# Altium schematic sheet Sheet1.SchDoc
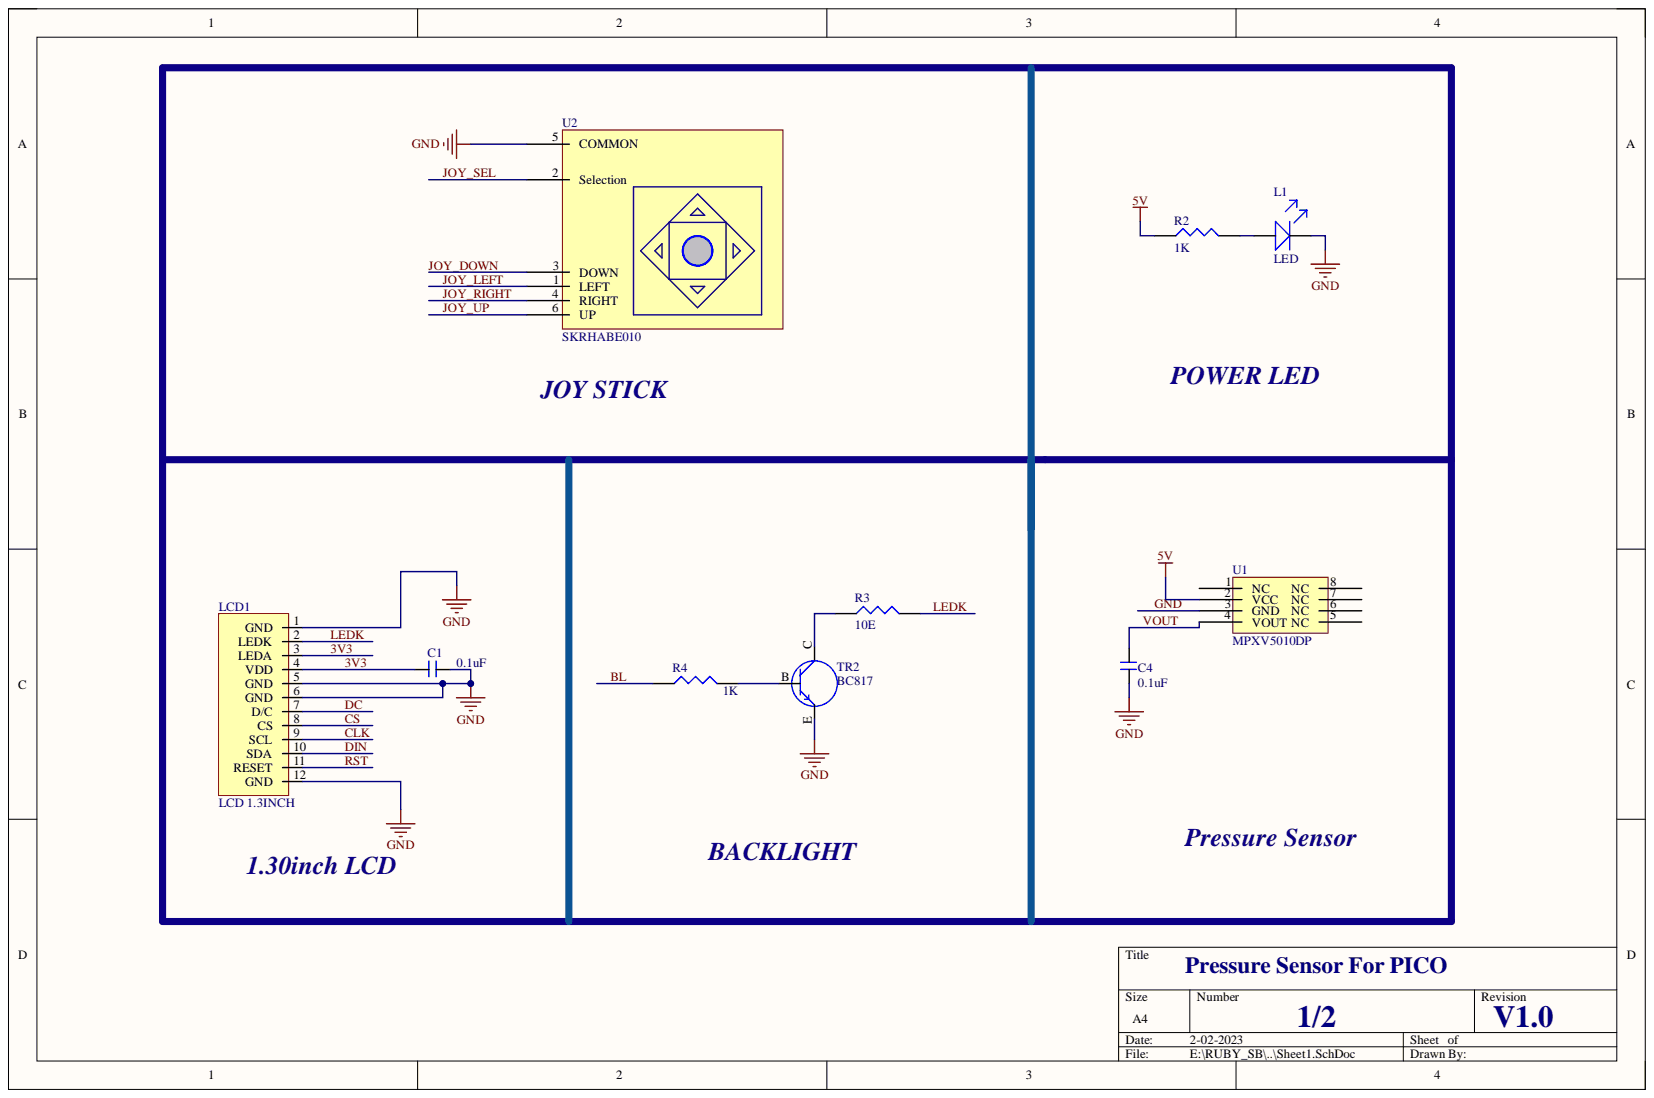
[diagram p0: Sheet1.SchDoc]

## Parts
C1  0.1uF  [C_0603]
C4  0.1uF  [C_0603]
L1  LED  [LED_0603]
LCD1  LCD 1.3INCH  [1.3inch LCD]
R2  1K  [R_0603]
R3  10E  [R_0603]
R4  1K  [R_0603]
TR2  BC817  [SOT23]
U1  MPXV5010DP  [MPXV5010DP]
U2  SKRHABE010  [JOY_STICK]

## Nets
5V: R2.1 U1.2(VCC)
3V3: C1.2 LCD1.3(LEDA) LCD1.4(VDD)
BL: R4.1
CLK: LCD1.9(SCL)
CS: LCD1.8(CS)
DC: LCD1.7(D/C)
DIN: LCD1.10(SDA)
GND: C1.1 C4.1 L1.K LCD1.1(GND) LCD1.5(GND) LCD1.6(GND) LCD1.12(GND) TR2.E U1.3(GND) U2.5(COMMON)
JOY_DOWN: U2.3(DOWN)
JOY_LEFT: U2.1(LEFT)
JOY_RIGHT: U2.4(RIGHT)
JOY_SEL: U2.2(Selection)
JOY_UP: U2.6(UP)
LEDK: LCD1.2(LEDK) R3.2
RST: LCD1.11(RESET)
VOUT: C4.2 U1.4(VOUT)
NetL1_A: L1.A R2.2
NetR3_1: R3.1 TR2.C
NetR4_2: R4.2 TR2.B

Not connected: U1 1(NC) 5(NC) 6(NC) 7(NC) 8(NC)

## Library data of the parts
C1 C4 = CAP_NONPOLAR: Component Kind: Standard; Library Name: dxp_pasl_lib.IntLib
LCD1 = LCD 1.3INCH: 1.3inch LCD, 240RGDX240 DOT MATIRX TFT LCD MODULE; Component Kind: Standard; Library Name: dxp_pasl_lib.IntLib
R2-R4 = RES: Component Kind: Standard; Library Name: dxp_pasl_lib.IntLib
TR2 = TRANSISTER_NPN: Component Kind: Standard; Library Name: dxp_pasl_lib.IntLib
U2 = SKRHABE010: SMD 5-way Tactile Switches RoHS #C139794; Component Kind: Standard; Library Name: dxp_pasl_lib.IntLib
L1 = LED: Component Kind: Standard; Library Name: dxp_pasl_lib.IntLib
U1 = MPXV5010DP: Component Kind: Standard; Library Name: dxp_pasl_lib.IntLib
